# Altium schematic sheet STM32WL_ADC.SchDoc
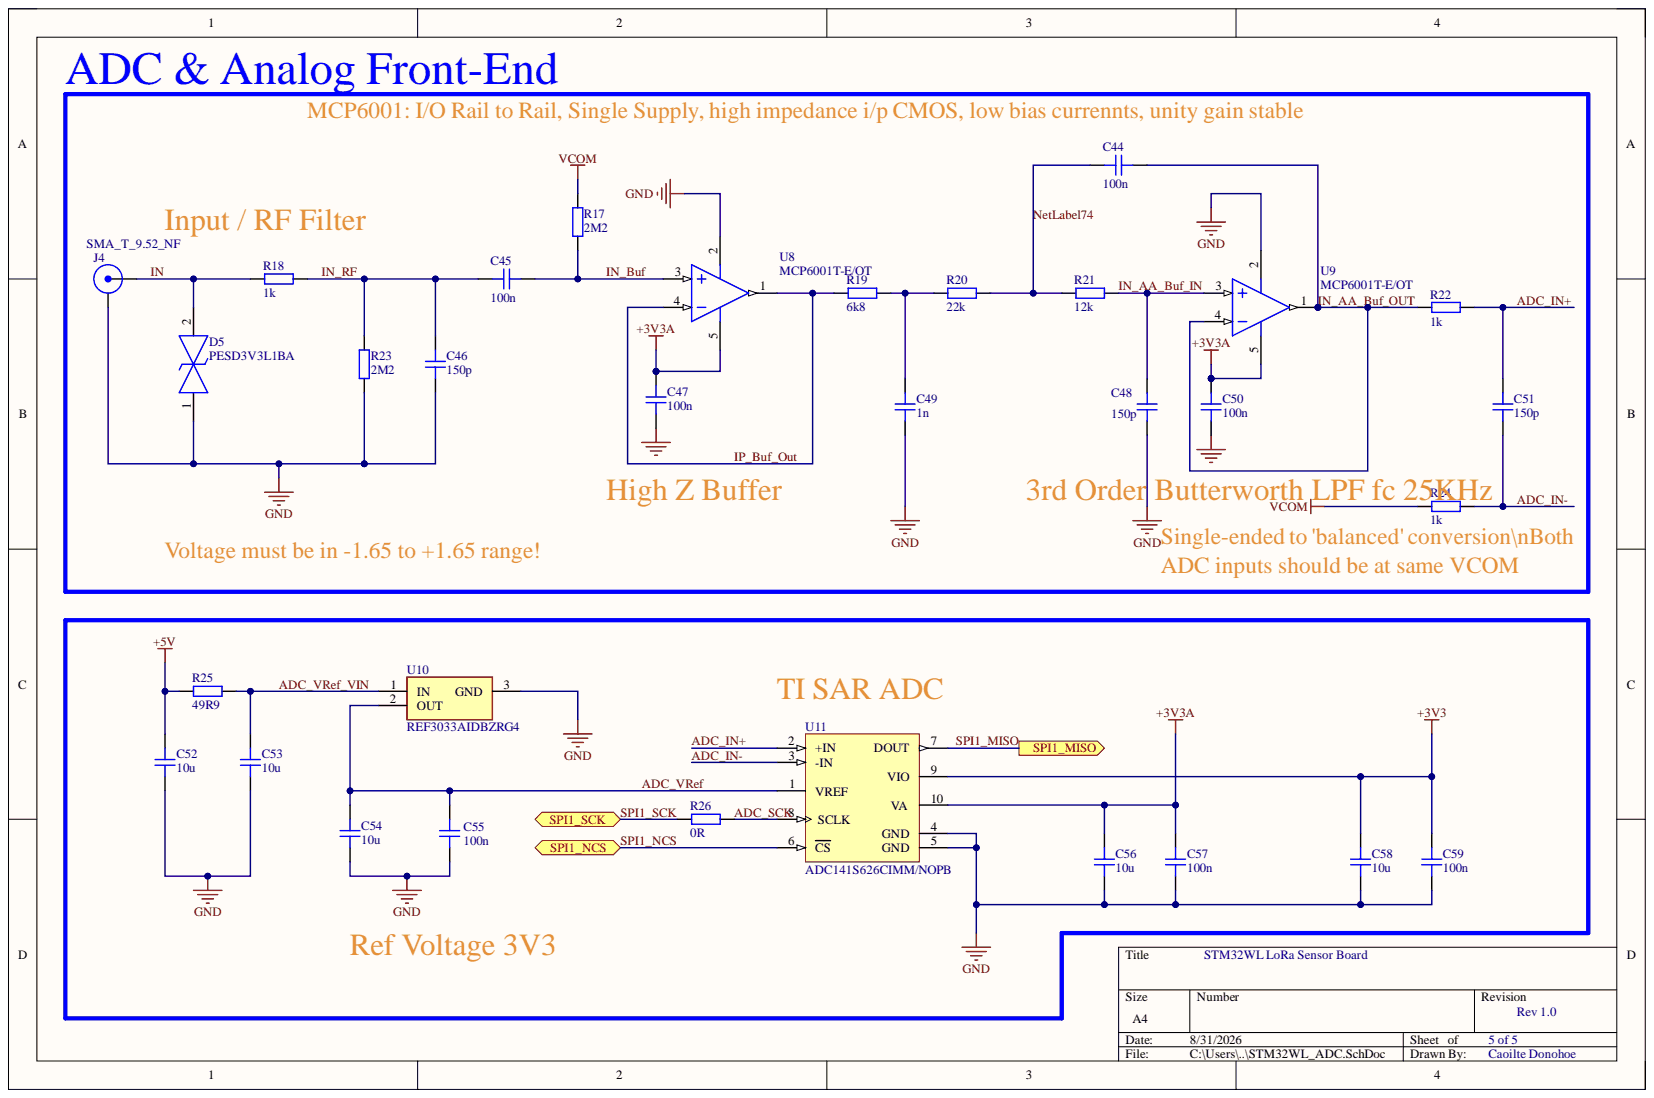
[diagram p0: STM32WL_ADC.SchDoc]

## Parts
C44  100n  [CAP_0402]
C45  100n  [CAP_0402]
C46  150p  [CAP_0402]
C47  100n  [CAP_0402]
C48  150p  [CAP_0402]
C49  1n  [CAP_0402]
C50  100n  [CAP_0402]
C51  150p  [CAP_0402]
C52  10u  [CAP_0603]
C53  10u  [CAP_0603]
C54  10u  [CAP_0603]
C55  100n  [CAP_0402]
C56  10u  [CAP_0603]
C57  100n  [CAP_0402]
C58  10u  [CAP_0603]
C59  100n  [CAP_0402]
D5  PESD3V3L1BA  [SOD2512X110N]
J4  SMA_T_9.52_NF  [CO_SAMTEC_SMA-J-P-ST-EMI]
R17  2M2  [RES_0402]
R18  1k  [RES_0402]
R19  6k8  [RES_0402]
R20  22k  [RES_0402]
R21  12k  [RES_0402]
R22  1k  [RES_0402]
R23  2M2  [RES_0402]
R24  1k  [RES_0402]
R25  49R9  [RES_0402]
R26  0R  [RES_0402]
U8  MCP6001T-E/OT  [SOT-23-OT5_L]
U9  MCP6001T-E/OT  [SOT-23-OT5_L]
U10  REF3033AIDBZRG4  [SOT95P237X112-3N]
U11  ADC141S626CIMM/NOPB  [MUB10A_M]

## Ports
SPI1_MISO, SPI1_NCS, SPI1_SCK

## Nets
+3V3: C58.2 C59.2 U11.9(VIO)
+3V3A: C47.1 C50.1 C56.2 C57.2 U8.5 U9.5 U11.10(VA)
+5V: C52.2 R25.1
GND: C46.1 C47.2 C48.1 C49.1 C50.2 C52.1 C53.1 C54.1 C55.1 C56.1 C57.1 C58.1 C59.1 D5.1 J4.2 R23.1 U8.2 U9.2 U10.3 U11.4(GND) U11.5(GND)
VCOM: R17.2 R24.2
ADC_IN+: C51.1 R22.1 U11.2(+IN)
ADC_IN-: C51.2 R24.1 U11.3(-IN)
ADC_SCK: R26.2 U11.8(SCLK)
ADC_VRef: C54.2 C55.2 U10.2 U11.1(VREF)
ADC_VRef_VIN: C53.2 R25.2 U10.1
IN: D5.2 J4.1 R18.1
IN_AA_Buf_IN: C48.2 R21.1 U9.3
IN_AA_Buf_OUT: C44.1 R22.2 U9.1 U9.4
IN_Buf: C45.1 R17.1 U8.3
IN_RF: C45.2 C46.2 R18.2 R23.2
IP_Buf_Out: R19.2 U8.1 U8.4
NetLabel74: C44.2 R20.1 R21.2
SPI1_MISO: U11.7(DOUT) ; port SPI1_MISO
SPI1_NCS: U11.6(CS) ; port SPI1_NCS
SPI1_SCK: R26.1 ; port SPI1_SCK
NetC49_2: C49.2 R19.1 R20.2

## Library data of the parts
C44-C51 C55 C57 C59 = CAP_0402_16V: Capacitor SMD 0402 16V; Component Kind: Standard; Library Name: Schematic-Lib-PhilsLab.SchLib
C52-C54 C56 C58 = CAP_0603_16V: Capacitor SMD 0603 16V; Component Kind: Standard; Library Name: Schematic-Lib-PhilsLab.SchLib
D5 = PESD3V3L1BA: TVS Diode (Bi-directional); Manufacturer_Name: Nexperia; Component Kind: Standard; Datasheet Link: https://datasheet.datasheetarchive.com/originals/distributors/Datasheets-26/DSA-502681.pdf; Height: 1.1mm; Manufacturer_Part_Number: PESD3V3L1BA; Mouser Part Number: N/A; Mouser Price/Stock: https://www.mouser.co.uk/ProductDetail/Nexperia/PESD3V3L1BA?qs=vHuUswq2%252BswBNSPO0jzUyg%3D%3D
J4 = SMA_T_9.52_NF: SMA End Launch Jack 1.0 mm Board, 50 ohm; Manufacturer: COAX CONNECTORS LTD; Manufacturer_Part_Number: 30-462-D3-1.1mm; Component Kind: Standard; Library Name: MB1789_HP.SCHLIB
R17-R26 = RES_0402: Resistor SMD 0402; Component Kind: Standard; Library Name: Schematic-Lib-PhilsLab.SchLib
U8 U9 = CMP-0187-00458-3: None; Amplifier Type: GENERAL PURPOSE; Bandwidth: 1MHz; Common Mode Rejection Ratio: 60dB; Input Bias Current: 1pA; Input Offset Voltage (Vos): 4.5mV; Max Supply Voltage: 6V; Min Supply Voltage: 1.8V; Nominal Supply Current: 100uA; Number of Amplifiers: 1; Operating Supply Voltage: 5.5V; Output Current: 23mA; Power Supply Rejection Ratio (PSRR): 86dB; Slew Rate: 0.6V/µs; Voltage Gain: 112dB; Case/Package: SOT-23; Component Kind: Standard; Contact Plating: Tin; Gain Bandwidth Product: 1MHz; Height: 1.3mm; Input Offset Voltage-Max: 4.5mV; Lead Free: Lead Free; Length: 3.1mm; Library Name: Altium Content Vault; Max Operating Temperature: 125°C; Min Operating Temperature: -40°C; Mount: Surface Mount; Number of Channels: 1; Number of Circuits: 1; Number of Elements: 1; Number of Pins: 5; Operating Supply Current: 100uA; Output Current per Channel: 23mA; Package Quantity: 3000; Packaging: Tape and Reel; Radiation Hardening: No; REACH SVHC: No SVHC; RoHS Compliant: Yes; Simulation: MCP6001; Slew Rate-Nom: 0.6V/µs; Supply Current: 100uA; Technology: CMOS; Unity Gain Bandwidth Product: 1MHz; Voltage: 6V; Width: 1.8mm
U10 = REF3033AIDBZRG4: Integrated Circuit; Manufacturer_Name: Texas Instruments; Arrow Part Number: REF3033AIDBZRG4; Arrow Price/Stock: https://www.arrow.com/en/products/ref3033aidbzrg4/texas-instruments; Component Kind: Standard; Datasheet Link: https://www.ti.com/lit/ds/symlink/ref3030.pdf?ts=1639296719724; Height: 1.12mm; Manufacturer_Part_Number: REF3033AIDBZRG4; Mouser Part Number: 595-REF3033AIDBZRG4; Mouser Price/Stock: https://www.mouser.co.uk/ProductDetail/Texas-Instruments/REF3033AIDBZRG4?qs=sSOk4GDDv7x3KhdEagQjiQ%3D%3D
U11 = CMP-0054-00477-2: 14-Bit, 50 kSPS to 250 kSPS, Differential Input, Micro Power A/D Converter, 10-pin Mini SOIC, Pb-Free; Manufacturer: National Semiconductor; CompType: ADC IC; PackageTopMarking: ZXTT*X94C; PowerWise: Y; Code_JEDEC: MO-187-BA; Component Kind: Standard; ComponentLink1Description: ADC141S626 Datasheet; ComponentLink1URL: http://www.national.com/ds/AD/ADC141S626.pdf; ComponentLink2Description: ADC141S626 Web Page; ComponentLink2URL: http://www.national.com/pf/AD/ADC141S626.html; ComponentLink3Description: MUB10A Package Page; ComponentLink3URL: http://www.national.com/packaging/folders/mub10a.html; DatasheetVersion: Jan-2009; Library Name: Altium Content Vault; PackageDescription: Mini-SO, Molded, .118 x .118 x .034in Body, 10 Lead, .0197in Pitch; PackageReference: MUB10A; PackageVersion: revB, Jul-2003; PinCount: 10; RevisionNote: Component URLs added; RoHS: Y
